# Altium schematic sheet STlinkV2.SchDoc
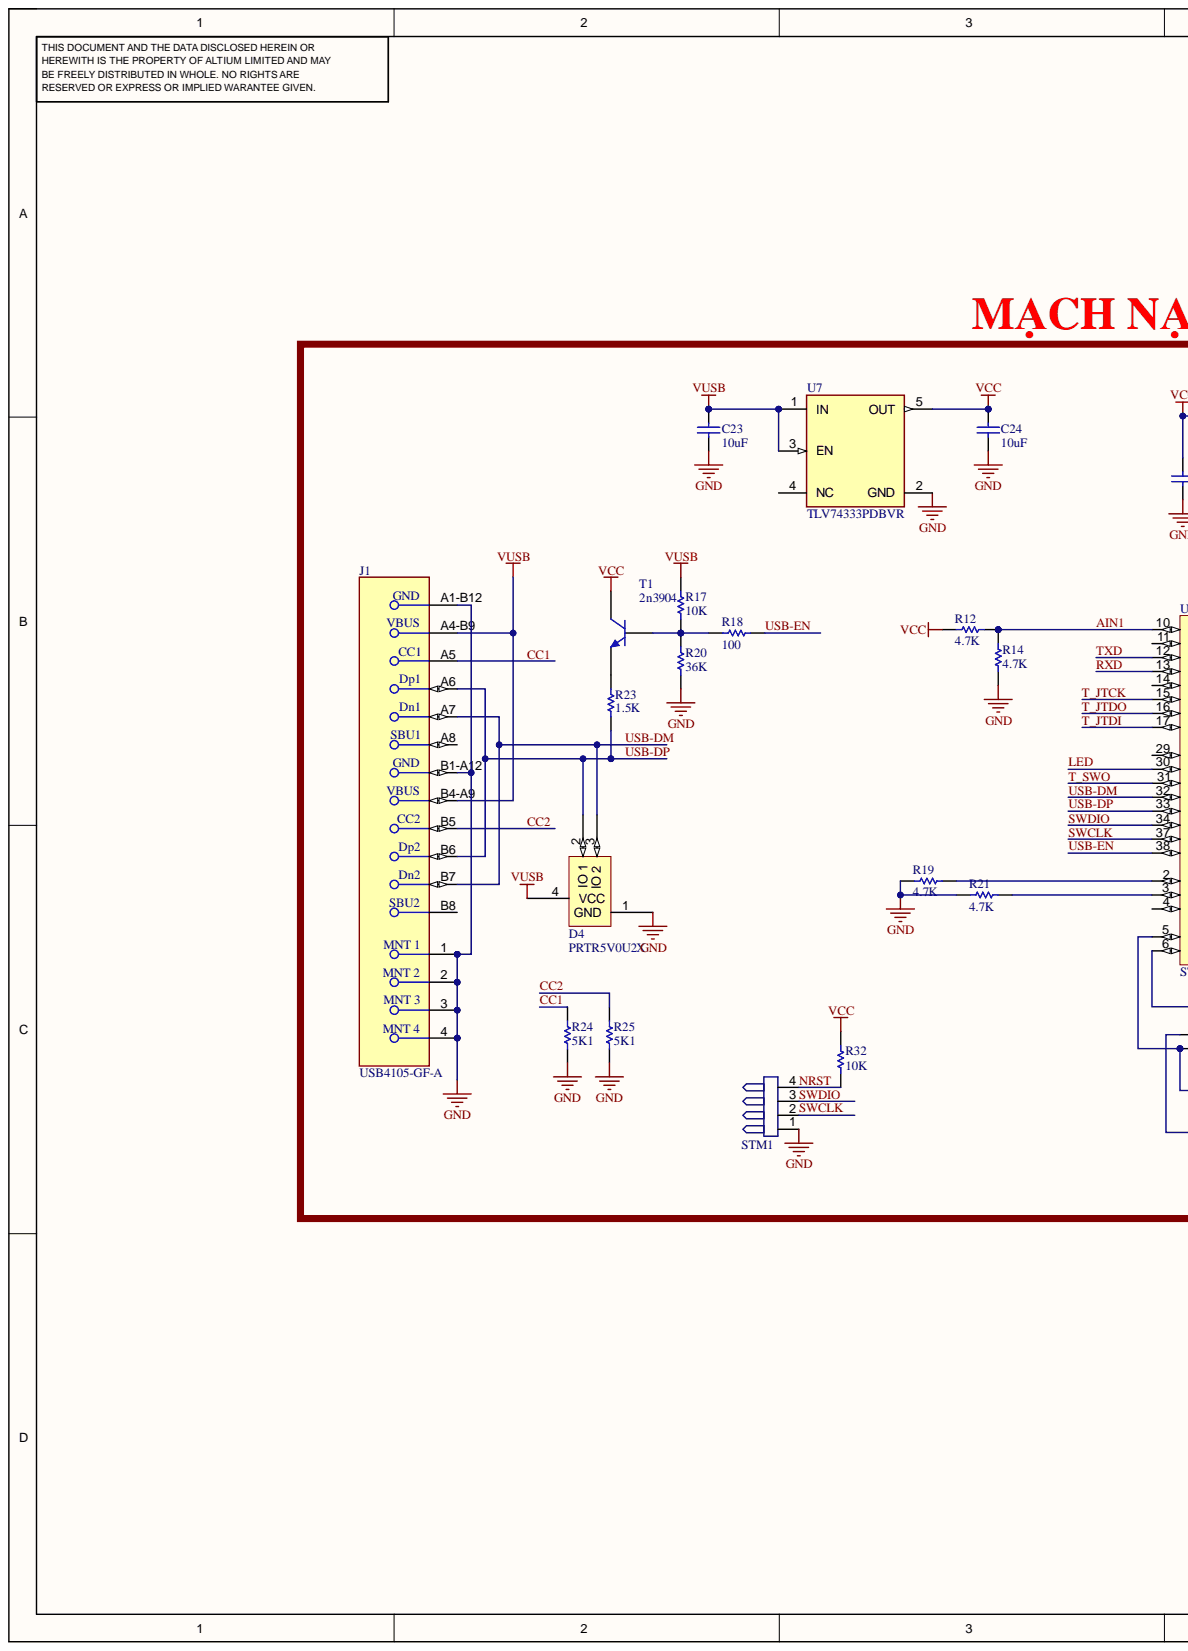
[diagram p0: STlinkV2.SchDoc, left]
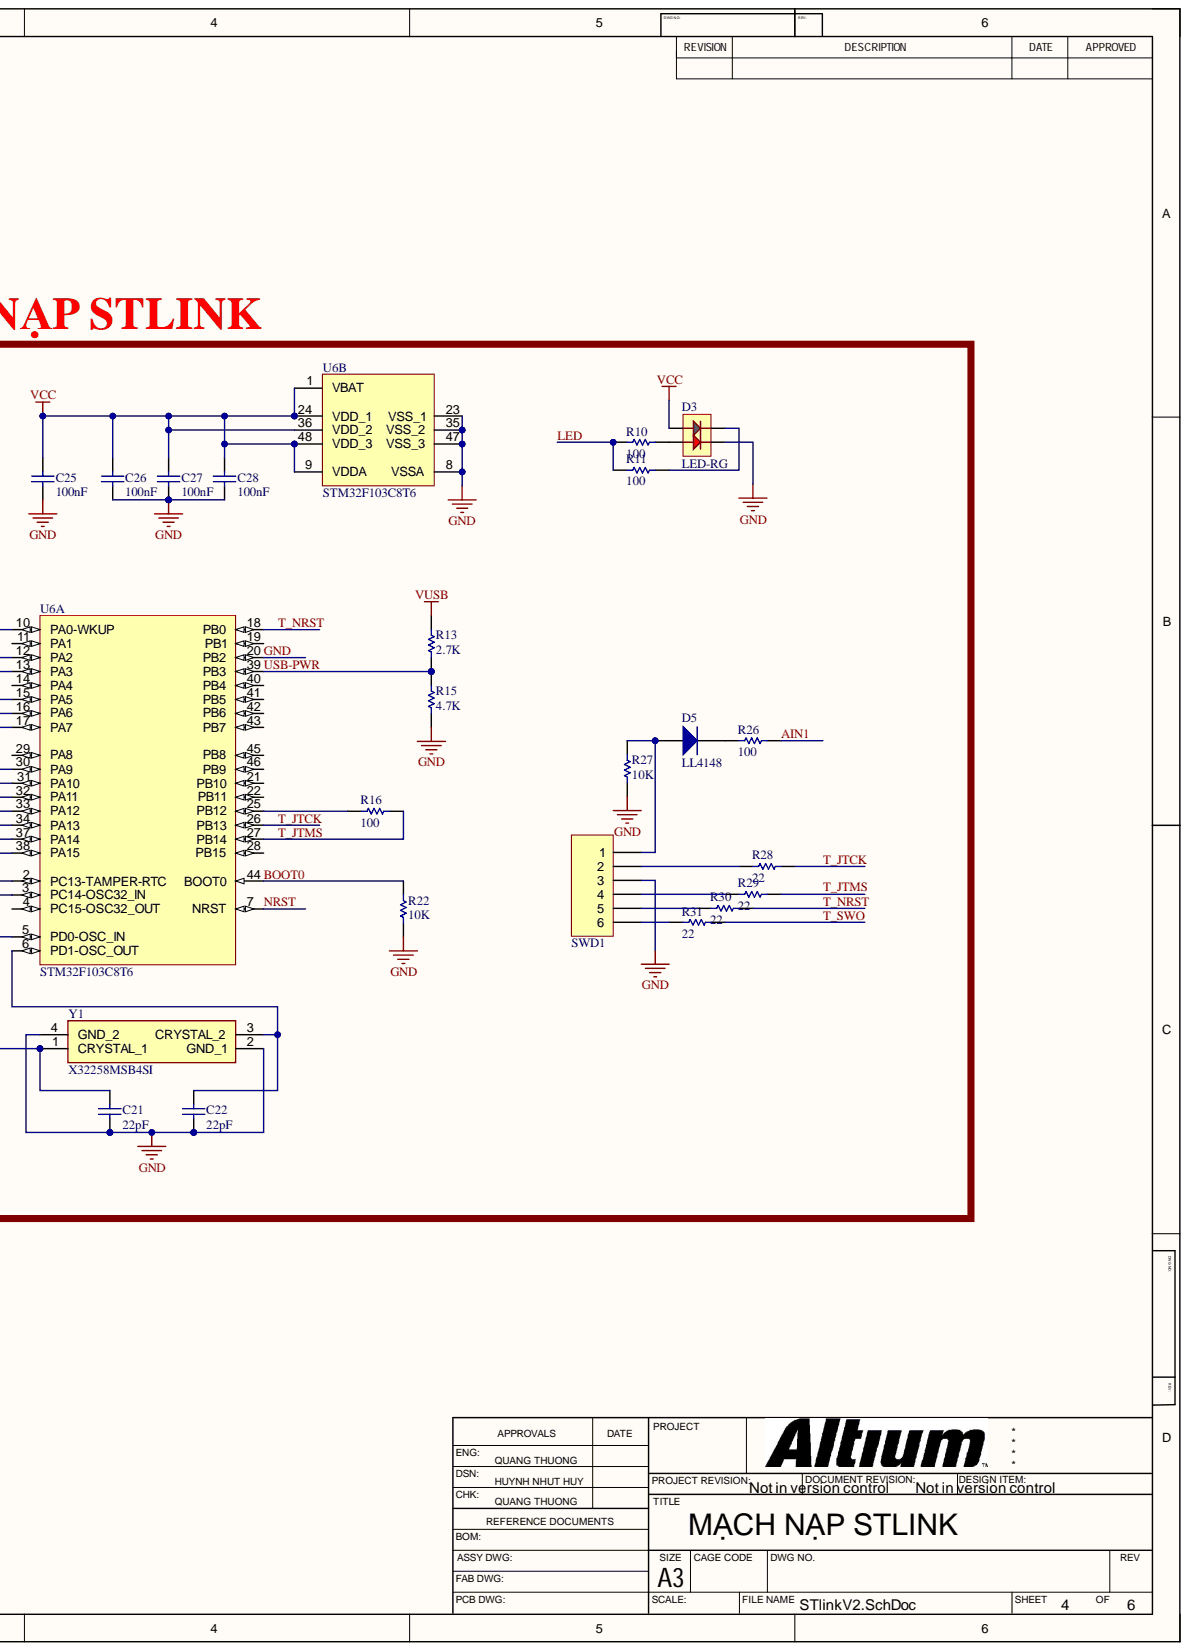
[diagram p1: STlinkV2.SchDoc, right]

## Parts
C21  22pF  [C603]
C22  22pF  [C603]
C23  10uF  [C805]
C24  10uF  [C805]
C25  100nF  [C603]
C26  100nF  [C603]
C27  100nF  [C603]
C28  100nF  [C603]
D3  LED-RG  [LED-1210]
D4  PRTR5V0U2X  [SOT-143B]
D5  LL4148  [SOD-80]
J1  USB4105-GF-A  [FP-USB4105-GF-A-MFG]
R10  100  [R0603]
R11  100  [R0603]
R12  4.7K  [R0603]
R13  2.7K  [R0603]
R14  4.7K  [R0603]
R15  4.7K  [R0603]
R16  100  [R0603]
R17  10K  [R0603]
R18  100  [R0603]
R19  4.7K  [R0603]
R20  36K  [R0603]
R21  4.7K  [R0603]
R22  10K  [R0603]
R23  1.5K  [R0603]
R24  5K1  [R0603]
R25  5K1  [R0603]
R26  100  [R0603]
R27  10K  [R0603]
R28  22  [R0603]
R29  22  [R0603]
R30  22  [R0603]
R31  22  [R0603]
R32  10K  [R0603]
STM1  PHDR 4  [PH-4]  (value not drawn)
SWD1  CONN-6  [XH-6]  (value not drawn)
T1  2n3904  [SOT-23-3]
U6  STM32F103C8T6  [LQFP-48]  (parts A,B)
U7  TLV74333PDBVR  [FP-DBV0005A-MFG]
Y1  X32258MSB4SI  [SMD3225_4P12288MHZ12PF10PPM4085]

## Nets
VCC: C24.2 C25.2 C26.2 C27.2 C28.2 D3.1 R12.2 R32.2 T1.1 U6.1(VBAT) U6.9(VDDA) U6.24(VDD_1) U6.36(VDD_2) U6.48(VDD_3) U7.5(OUT)
VUSB: C23.2 D4.4(VCC) J1.A4-B9 J1.B4-A9 R13.1 R17.1 U7.1(IN) U7.3(EN)
AIN1: R12.1 R14.2 R26.2 U6.10(PA0-WKUP)
BOOT0: R22.2 U6.44(BOOT0)
CC1: J1.A5 R24.1
CC2: J1.B5 R25.1
GND: C21.1 C22.1 C23.1 C24.1 C25.1 C26.1 C27.1 C28.1 D3.3 D4.1(GND) J1.1 J1.2 J1.3 J1.4 J1.A1-B12 J1.B1-A12 R14.1 R15.1 R19.2 R20.2 R21.2 R22.1 R24.2 R25.2 R27.2 STM1.1 SWD1.3 U6.8(VSSA) U6.20(PB2) U6.23(VSS_1) U6.35(VSS_2) U6.47(VSS_3) U7.2(GND) Y1.2(GND_1) Y1.4(GND_2)
LED: R10.2 R11.2 U6.30(PA9)
NRST: R32.1 STM1.4 U6.7(NRST)
RXD: U6.13(PA3)
SWCLK: STM1.2 U6.37(PA14)
SWDIO: STM1.3 U6.34(PA13)
T_JTCK: R28.1 U6.15(PA5) U6.26(PB13)
T_JTDI: U6.17(PA7)
T_JTDO: U6.16(PA6)
T_JTMS: R16.1 R29.1 U6.27(PB14)
T_NRST: R30.1 U6.18(PB0)
T_SWO: R31.1 U6.31(PA10)
TXD: U6.12(PA2)
USB-DM: D4.3(IO_2) J1.A7 J1.B7 U6.32(PA11)
USB-DP: D4.2(IO_1) J1.A6 J1.B6 R23.2 U6.33(PA12)
USB-EN: R18.2 U6.38(PA15)
USB-PWR: R13.2 R15.2 U6.39(PB3)
NetC21_2: C21.2 U6.5(PD0-OSC_IN) Y1.1(CRYSTAL_1)
NetC22_2: C22.2 U6.6(PD1-OSC_OUT) Y1.3(CRYSTAL_2)
NetD3_2: D3.2 R10.1
NetD3_4: D3.4 R11.1
NetD5_1: D5.1 R27.1 SWD1.1
NetD5_2: D5.2 R26.1
NetR16_2: R16.2 U6.25(PB12)
NetR17_2: R17.2 R18.1 R20.1 T1.3
NetR19_1: R19.1 U6.2(PC13-TAMPER-RTC)
NetR21_1: R21.1 U6.3(PC14-OSC32_IN)
NetR23_1: R23.1 T1.2
NetR28_2: R28.2 SWD1.2
NetR29_2: R29.2 SWD1.4
NetR30_2: R30.2 SWD1.5
NetR31_2: R31.2 SWD1.6

Not connected: J1 A8 B8; U6 4(PC15-OSC32_OUT) 11(PA1) 14(PA4) 19(PB1) 21(PB10) 22(PB11) 28(PB15) 29(PA8) 40(PB4) 41(PB5) 42(PB6) 43(PB7) 45(PB8) 46(PB9); U7 4(NC)

## Library data of the parts
C21-C28 = Cap: Capacitor; Designer: Parsa Malekpour; Component Kind: Standard; Library Name: ParsaLibrary.SchLib
  C21 C22: Value: 22pF
  C23 C24: Value: 10uF
  C25-C28: Value: 100nF
D3 = LED-RG-4: Component Kind: Standard; Library Name: ParsaLibrary.SchLib
D4 = PRTR5V0U2X: Ultra low capacitance double rail-to-rail ESD protection diode; reverse standoff voltage: 5.5V; Component Kind: Standard; Library Name: ParsaLibrary.SchLib
J1 = CMP-245163-000001-1: CONN RCPT USB2.0 TYPEC 5A; Manufacturer: GCT; Conn Orientation: Right Angle; Current Rating: 5/6.25/1.25/0.25A; Is Female: yes; Number Of Contacts: 16; Voltage Rating: 20V; Automotive: No; Category: Conn; Component Kind: Standard; ComponentLink2Description: Datasheet; ComponentLink2URL: https://gct.co/files/drawings/usb4105.pdf; Contact Material: Gold,Nickel; Device Class L1: Connectors; Device Class L2: USB Connectors; Device Class L3: unset; Digikey Description: CONN RCPT USB2.0 TYPEC 5A; Height: 3.46mm; Lead Free: Yes; Library Name: Altium Content Vault; Manufacturer Part Number: USB4105-GF-A; Octopart: https://octopart.com/usb4105-gf-a-gct-106627878; Package: 0; RoHS: TRUE; Temperature: -40°C to +85°C; Temperature Range High: +85°C; Temperature Range Low: -40°C; Usb Standard: USB 2.0
R10-R32 = Resistor: Designer: Parsa Malekpour; Component Kind: Standard; Description: Resistor; Library Name: ParsaLibrary.SchLib
  R10 R11 R16 R18 R26: Value: 100
  R12 R14 R15 R19 R21: Value: 4.7K
  R13: Value: 2.7K
  R17 R22 R27 R32: Value: 10K
  R20: Value: 36K
  R23: Value: 1.5K
  R24 R25: Value: 5K1
  R28-R31: Value: 22
STM1 = PHDR 4: PinHeader; Component Kind: Standard; Library Name: ParsaLibrary.SchLib
T1 = 2n3904: Mark: 1A; Component Kind: Standard; Library Name: ParsaLibrary.SchLib
U6 = STM32F103C8T6: ARM Cortex-M3 32-bit MCU, 64 KB Flash, 20 KB Internal RAM, 37 I/Os, 48-pin LQFP, -40 to 85 degC, Tray; A/D Converter: 2x12-bit (10 Channel); FLASH Size (Prog) (kB): 64; I/Os (High Current): 37; Internal RAM Size (kB): 20; Operating Frequency(F) (Processor speed) (MHz): 72; Supply Voltage(Vcc) min (V): 2; Component Kind: Standard; ComponentLink1Description: Manufacturer URL; ComponentLink1URL: http://www.st.com/web/en/home.html; ComponentLink2Description: Datasheet; ComponentLink2URL: http://www.st.com/st-web-ui/static/active/en/resource/technical/document/datasheet/CD00161566.pdf; ComponentLink3Description: Package Specification; ComponentLink3URL: http://www.st.com/st-web-ui/static/active/en/resource/technical/document/datasheet/DM00058181.pdf; Core: ARM Cortex-M3; DatasheetVersion: Rev. 16; Library Name: STMicroelectronics STM32 F1.IntLib; Mounting Technology: Surface Mount; PackageDescription: 48-Pin Low Profile Quad Flat Package, 7 x 7 mm Body, 0.5 mm Pitch; PackageReference: LQFP48; PackageVersion: Rev. 6, 06/2013; Packing: Tray; PartNumber: STM32F103C8T6; Supplier 1: Digi-Key; Supplier 2: Farnell; Supplier 3: Newark; Supplier 4: Newark; Supplier 5: Mouser; Supplier Part Number 1: 497-6063-ND; Supplier Part Number 2: 1447637; Supplier Part Number 3: 59M2182; Supplier Part Number 4: 57P1222; Supplier Part Number 5: 511-STM32F103C8T6
U7 = CMP-04917-000051-1: IC REG LINEAR 3.3V 300MA SOT23-5; Manufacturer: Texas Instruments; Accuracy Percentage: 1%; Dropout Voltage: 125mV; Max Junction Temp: +125°C; Max Supply Voltage: 5.5V; Min Supply Voltage: 1.4V; Nominal Supply Current: 34uA; Number Of Outputs: 1; Output Type: Fixed; Output Voltage: 1-3.3V; Standoff Height: 0mm; Automotive: No; Category: IC; Component Kind: Standard; ComponentLink2Description: Datasheet; ComponentLink2URL: http://www.ti.com/lit/ds/symlink/tlv743p.pdf; Device Class L1: Integrated Circuits (ICs); Device Class L2: Power Management ICs; Device Class L3: Voltage Regulators - Linear; Digikey Description: IC REG LINEAR 3.3V 300MA SOT23-5; Height: 1.45mm; Lead Free: Yes; Library Name: Altium Content Vault; Manufacturer Part Number: TLV74333PDBVR; Mouser Description: 300mA Low-Dropout (LDO) Regulator 5-SOT-23 -40 to 125; Octopart: https://octopart.com/tlv74333pdbvr-texas+instruments-84791760; Output Current: 300mA; Package: DBV0005A; RoHS: TRUE; Temperature: -40°C to +125°C; Temperature Range High: +125°C; Temperature Range Low: -40°C
Y1 = SMD-3225_4P_12.288MHZ_12PF_10PPM_-40~+85: Crystal or Oscillator; Manufacturer_Name: TAE(Zhejiang Abel Elec); Manufacturer_Part_Number: SMD-3225_4P 12.288MHZ 12PF 10PPM -40~+85℃; Component Kind: Standard; Datasheet Link: https://datasheet.lcsc.com/szlcsc/1901241506_Zhejiang-Abel-Elec-SMD-3225-4P-12-288MHZ-12PF-10PPM-40-85_C362472.pdf; Height: 0.8mm
D5 = LL4148: Component Kind: Standard; Library Name: ParsaLibrary.SchLib
SWD1 = CONN-6: Component Kind: Standard; Library Name: ParsaLibrary.SchLib
